# Altium schematic sheet [08] PCIe.SchDoc("[08] PCIe")
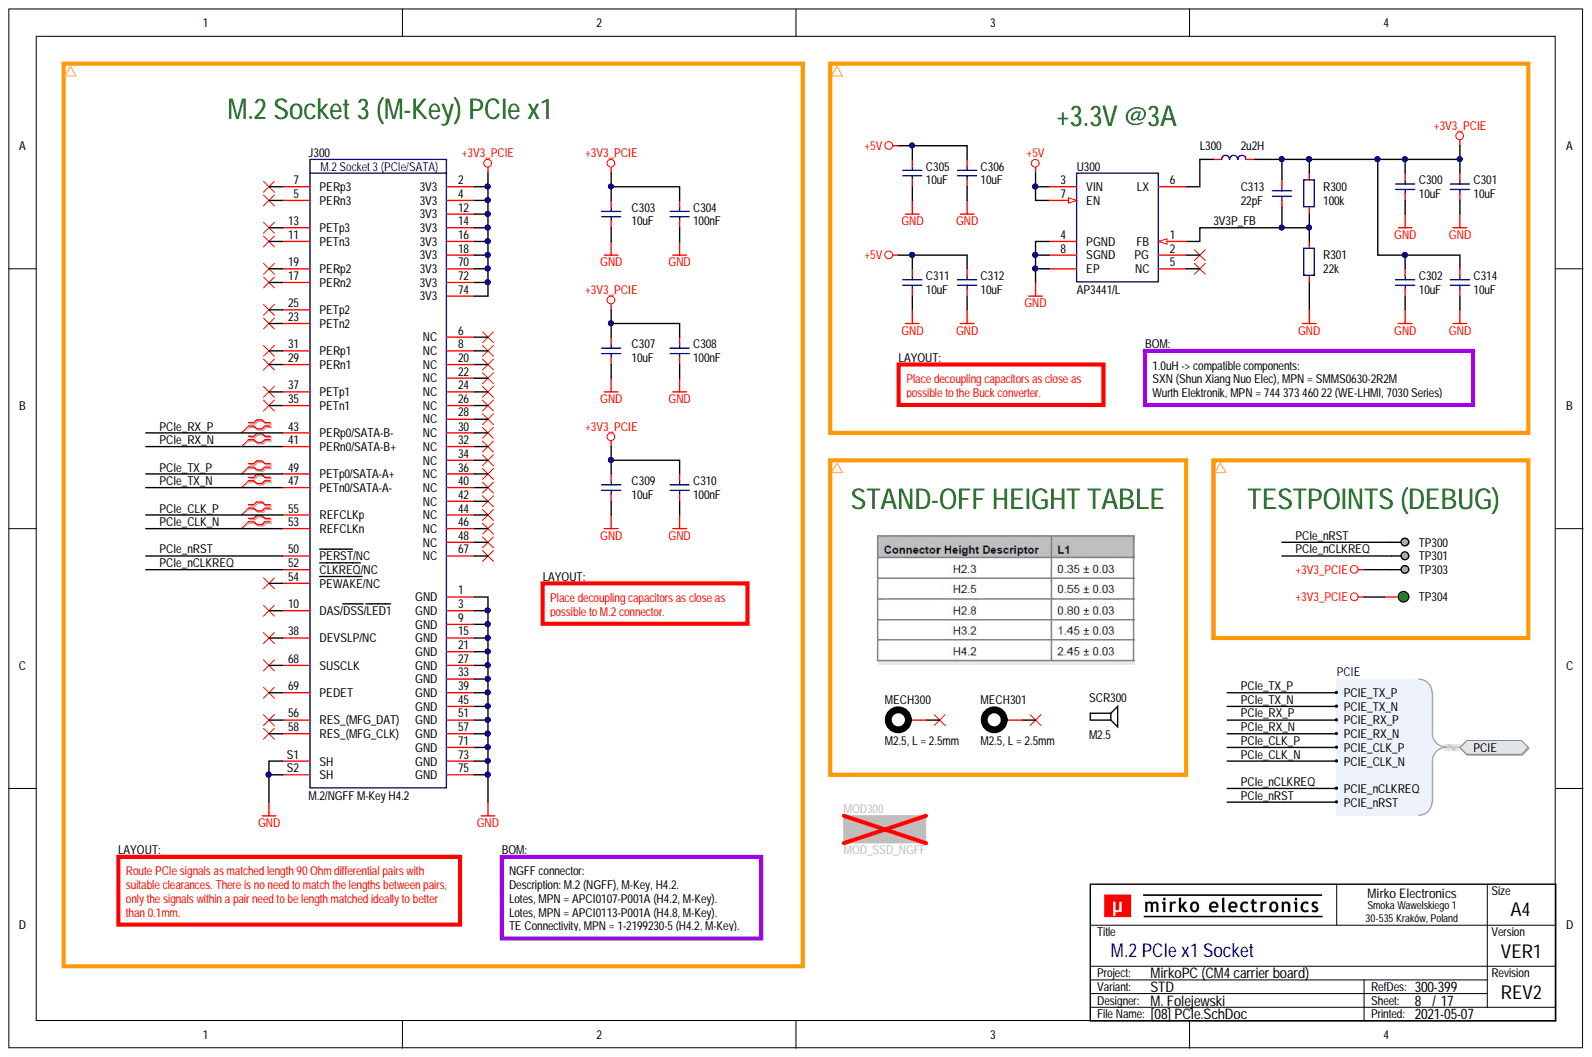
[diagram p0: [08] PCIe.SchDoc]

## Parts
C300  10uF  [CAP_0603_R]
C301  10uF  [CAP_0603_R]
C302  10uF  [CAP_0603_R]
C303  10uF  [CAP_0603_R]
C304  100nF  [CAP_0603_R]
C305  10uF  [CAP_0603_R]
C306  10uF  [CAP_0603_R]
C307  10uF  [CAP_0603_R]
C308  100nF  [CAP_0603_R]
C309  10uF  [CAP_0603_R]
C310  100nF  [CAP_0603_R]
C311  10uF  [CAP_0603_R]
C312  10uF  [CAP_0603_R]
C313  22pF  [CAP_0603_R]
C314  10uF  [CAP_0603_R]
J300  M.2/NGFF M-Key H4.2  [CON_NGFF_KEY-M_P67_H420]
L300  2u2H  [IND_WRT_7030_730X660H280_R]
MECH300  M2.5, L = 2.5mm  [PCB_WRT_9774030151]
MECH301  M2.5, L = 2.5mm  [PCB_WRT_9774030151]
MOD300  MOD_SSD_NGFF  [MOD_SSD_NGFF_2280_B+M_KEY_H247]
R300  100k  [RES_0603_R]
R301  22k  [RES_0603_R]
SCR300  M2.5  (mechanical)
TP300  DEBUG  [PCB_TP_DBG_50]  (no BOM)
TP301  DEBUG  [PCB_TP_DBG_50]  (value not drawn)  (no BOM)
TP303  DEBUG  [PCB_TP_DBG_50]  (value not drawn)  (no BOM)
TP304  ICT  [PCB_TP_ICT_100]  (value not drawn)  (no BOM)
U300  AP3441/L  [ICC_DFN8_200X200X90P50_EP_R]

## Ports
PCIE, PCIE.PCIE_CLK_N, PCIE.PCIE_CLK_P, PCIE.PCIE_nCLKREQ, PCIE.PCIE_nRST, PCIE.PCIE_RX_N, PCIE.PCIE_RX_P, PCIE.PCIE_TX_N, PCIE.PCIE_TX_P

## Nets
+5V: C305.2 C306.2 C311.2 C312.2 U300.3(VIN) U300.7(EN)
GND: C300.1 C301.1 C302.1 C303.1 C304.1 C305.1 C306.1 C307.1 C308.1 C309.1 C310.1 C311.1 C312.1 C314.1 J300.1(GND) J300.3(GND) J300.9(GND) J300.15(GND) J300.21(GND) J300.27(GND) J300.33(GND) J300.39(GND) J300.45(GND) J300.51(GND) J300.57(GND) J300.71(GND) J300.73(GND) J300.75(GND) J300.S1(SH) J300.S2(SH) R301.1 U300.4(PGND) U300.8(SGND) U300.EP
+3V3_PCIE: C300.2 C301.2 C302.2 C303.2 C304.2 C307.2 C308.2 C309.2 C310.2 C313.1 C313.2 C314.2 J300.2(3V3) J300.4(3V3) J300.12(3V3) J300.14(3V3) J300.16(3V3) J300.18(3V3) J300.70(3V3) J300.72(3V3) J300.74(3V3) L300.2 R300.1 R300.2 R301.2 TP303.1 TP304.1 U300.1(FB)  [also labelled 3V3P_FB]
PCIe_CLK_N: J300.53(REFCLKn) ; port PCIE
PCIe_CLK_P: J300.55(REFCLKp) ; port PCIE
PCIe_nCLKREQ: J300.52(CLKREQ/NC) TP301.1 ; port PCIE
PCIe_nRST: J300.50(PERST/NC) TP300.1 ; port PCIE
PCIe_RX_N: J300.41(PERn0/SATA-B+) ; port PCIE
PCIe_RX_P: J300.43(PERp0/SATA-B-) ; port PCIE
PCIe_TX_N: J300.47(PETn0/SATA-A-) ; port PCIE
PCIe_TX_P: J300.49(PETp0/SATA-A+) ; port PCIE
NetL300_1: L300.1 U300.6(LX)

Not connected: J300 5(PERn3) 6(NC) 7(PERp3) 8(NC) 10(DAS/DSS/LED1) 11(PETn3) 13(PETp3) 17(PERn2) 19(PERp2) 20(NC) 22(NC) 23(PETn2) 24(NC) 25(PETp2) 26(NC) 28(NC) 29(PERn1) 30(NC) 31(PERp1) 32(NC) 34(NC) 35(PETn1) 36(NC) 37(PETp1) 38(DEVSLP/NC) 40(NC) 42(NC) 44(NC) 46(NC) 48(NC) 54(PEWAKE/NC) 56(RES_(MFG_DAT)) 58(RES_(MFG_CLK)) 67(NC) 68(SUSCLK) 69(PEDET); MECH300 1; MECH301 1; U300 2(PG) 5(NC)

## Library data of the parts
C300-C303 C305-C307 C309 C311-C314 = CAP-0023: Capacitor; MLCC; GENERIC; TBD; TBD; TBD; TBD; SMD; 0603 (1608);; Database Table Name: CAPACITOR$; Supplier: ?; Package (Case): 0603 (1608); MPN: GENERIC; Mounting Type: SMD; Manufacturer: GENERIC; Component Type: Capacitor; Component Subtype: MLCC; Component Kind: Standard; Library Name: MirFol_db_local.DbLib
  C300-C303 C305-C307 C309 C311 C312 C314: Value: 10uF
  C313: Value: 22pF
C304 C308 C310 = CAP-0008: Capacitor; MLCC; 100nF; TBD; TBD; TBD; TBD; SMD; 0603 (1608);; Database Table Name: CAPACITOR$; Value: 100nF; Supplier: ?; Package (Case): 0603 (1608); MPN: TBD; Mounting Type: SMD; Manufacturer: TBD; Component Type: Capacitor; Component Subtype: MLCC; Component Kind: Standard; Library Name: MirFol_db_local.DbLib
J300 = CON_NGFF_M-KEY_A2: Connector; NGFF (M.2); M-Key;; MPN: APCI0107-P001A; Manufacturer: Lotes; Package (Case): SMD; Component Subtype: M.2 Socket (M-Key); Value: M.2/NGFF M-Key H4.2; Supplier: ?; Mounting Type: SMD; Component Type: Connector; Component Kind: Standard; Library Name: MirFol_lib.SchLib
L300 = IND: Inductor;; MPN: SMMS0630-2R2M; Manufacturer: SXN (Shun Xiang Nuo Elec); Package (Case): SMD; Component Subtype: Power Inductor; Value: 2u2H; Supplier: ?; Mounting Type: SMD; Component Type: Inductor; Component Kind: Standard; Library Name: MirFol_lib.SchLib
MECH300 MECH301 = PCB_MECH_WRT_WA-SMSI: PCB; Mechanical; SMT Steel Spacer; M2.5;; MPN: 977 402 515 1; Manufacturer: Wurth Elektronik; Package (Case): SMD; Component Subtype: SMD Spacer; Value: M2.5, L = 2.5mm; Supplier: Wurth Elektronik; Mounting Type: SMD; Component Type: Spacer (Standoff); Component Kind: Standard; Library Name: MirFol_lib.SchLib
MOD300 = MOD_SSD_NGFF: Module; SSD Disk; NGFF M.2;; Supplier: ?; Package (Case): -; Mounting Type: -; Component Type: M.2 Module (NGFF); Component Subtype: -; Component Kind: Net Tie; Library Name: MirFol_lib.SchLib
R300 = RES-0029: Resistor; Thick Film; 100k; 1%; 100mW; 100PPM; 75V; Standard; SMD; 0603 (1608);; MPN: TBD; Manufacturer: Yageo Corporation; Database Table Name: RESISTOR$; Value: 100k; Supplier: ?; Package (Case): 0603 (1608); Mounting Type: SMD; Component Type: Resistor; Component Subtype: Thick Film; Component Kind: Standard; Library Name: MirFol_db_local.DbLib
R301 = RES-0034: Resistor; Thick Film; 22k; 1%; 100mW; 100PPM; 75V; Standard; SMD; 0603 (1608);; MPN: TBD; Manufacturer: Yageo Corporation; Database Table Name: RESISTOR$; Value: 22k; Supplier: ?; Package (Case): 0603 (1608); Mounting Type: SMD; Component Type: Resistor; Component Subtype: Thick Film; Component Kind: Standard; Library Name: MirFol_db_local.DbLib
SCR300 = PCB_MECH_SCR: Mechnical; Screw;; MPN: M2.5 screw; Value: M2.5; Library Name: MirFol_lib.SchLib
TP300 TP301 TP303 = PCB_TP_DBG: PCB Marking; Test Point (Debug);; Value: DEBUG; Supplier: ?; Mounting Type: -; Component Type: Testpoint; Component Subtype: -; Library Name: MirFol_lib.SchLib
TP304 = PCB_TP_ICT: Test Point (ICT); Specification: Testpoint (for ICT), SMD pad; Value: ICT; MPN: -; Mounting Type: Non component; Manufacturer: -; Component Type: Testpoint (for ICT); Library Name: MirFol_lib.SchLib
U300 = ICC_DDS_AP3441/L_DFN2020-8: IC; Buck regulator;; MPN: AP3441SHE-7B; Manufacturer: Diodes Incorporated; Specification: Buck converter, 1MHz, 3A, U-DFN2020-8 package; Value: AP3441/L; Package: U-DFN2020-8; Mounting Type: SMD; Component Type: IC; Component Kind: Standard; Library Name: MirFol_lib.SchLib
